# Altium schematic sheet Sheet1.SchDoc
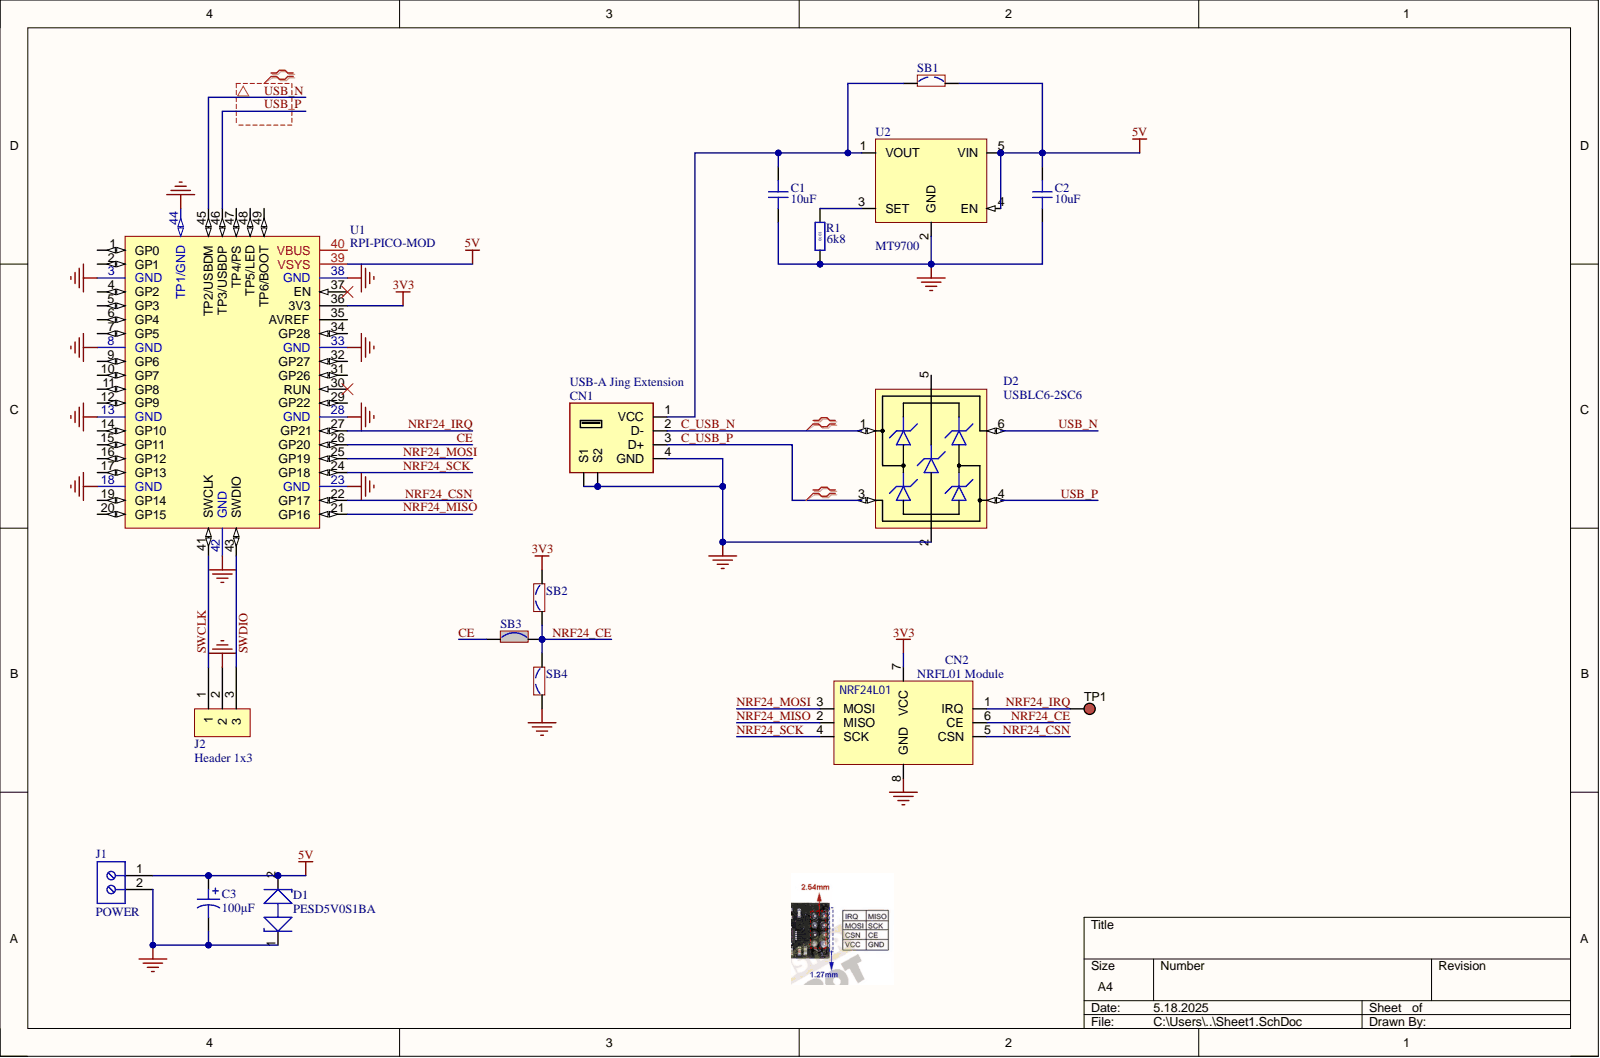
[diagram p0: Sheet1.SchDoc]

## Parts
C1  10uF  [CAP_0603]
C2  10uF  [CAP_0603]
C3  100µF  [CAP TH ALUM ELEC D5.00mm S2.00mm H12.50mm]
CN1  USB-A Jing Extension  [USB-A Jing Extension-Footprint-1]
CN2  NRFL01 Module  [Copy of HDR2X4]
D1  PESD5V0S1BA  Rep Pk Reverse Voltage-Max: 5V  [FP-SOD323-CA-MFG]
D2  USBLC6-2SC6  [SOT-23-6]
J1  POWER  [691102710002]
J2  Header 1x3  [Header 1x3 male]
R1  6k8  [RES_0603]
SB1  SB_OFF  [RESC1608X55X25ML10T15]  (value not drawn)
SB2  SB_OFF  [RESC1608X55X25ML10T15]  (value not drawn)
SB3  SB_ON  [RESC1608X55X25ML10T15]  (value not drawn)
SB4  SB_OFF  [RESC1608X55X25ML10T15]  (value not drawn)
TP1  5003  [KSTN-5003]  (value not drawn)
U1  RPI-PICO-MOD  [RPI-PICO-MOD]
U2  MT9700  [SOT-23-5]

## Nets
3V3: CN2.7(VCC) SB2.2 U1.36(3V3)
5V: C2.2 C3.1 D1.2 J1.1 SB1.2 U1.39(VSYS) U2.4(EN) U2.5(VIN)
GND: C1.1 C2.1 C3.2 CN1.4(GND) CN1.5(S1) CN1.6(S2) CN2.8(GND) D1.1 D2.2 J1.2 J2.2 R1.1 SB4.1 U1.3(GND) U1.8(GND) U1.13(GND) U1.18(GND) U1.23(GND) U1.28(GND) U1.33(GND) U1.38(GND) U1.42(GND) U1.44(TP1/GND) U2.2(GND)
C_USB_N: CN1.2(D-) D2.1
C_USB_P: CN1.3(D+) D2.3
CE: SB3.1 U1.26(GP20)
NRF24_CE: CN2.6(CE) SB2.1 SB3.2 SB4.2
NRF24_CSN: CN2.5(CSN) U1.22(GP17)
NRF24_IRQ: CN2.1(IRQ) TP1.1 U1.27(GP21)
NRF24_MISO: CN2.2(MISO) U1.21(GP16)
NRF24_MOSI: CN2.3(MOSI) U1.25(GP19)
NRF24_SCK: CN2.4(SCK) U1.24(GP18)
SWCLK: J2.1 U1.41(SWCLK)
SWDIO: J2.3 U1.43(SWDIO)
USB_N: D2.6 U1.45(TP2/USBDM)
USB_P: D2.4 U1.46(TP3/USBDP)
NetC1_2: C1.2 CN1.1(VCC) SB1.1 U2.1(VOUT)
NetR1_2: R1.2 U2.3(SET)

Not connected: D2 5; U1 1(GP0) 2(GP1) 4(GP2) 5(GP3) 6(GP4) 7(GP5) 9(GP6) 10(GP7) 11(GP8) 12(GP9) 14(GP10) 15(GP11) 16(GP12) 17(GP13) 19(GP14) 20(GP15) 29(GP22) 30(RUN) 31(GP26) 32(GP27) 34(GP28) 35(AVREF) 37(EN) 40(VBUS) 47(TP4/PS) 48(TP5/LED) 49(TP6/BOOT)

## Library data of the parts
C1 C2 = CMP-001-00086-1: Capacitor SMD 0603; Component Kind: Standard; Design Item ID: CAP_0603; Library Name: Simon Mayr; Source: Schematic-Lib-PhilsLab.SchLib
C3 = 16PX100MEFC5X11: CAP ALUM 100UF 20% 16V RADIAL; manufacturer: Rubycon; Database Table Name: Capacitors - Aluminum - Electrolytic TH; Lifetime @ Temp.: 1000 Hrs @ 105°C; Polarization: Polar; Ripple Current @ High Frequency: 156mA @ 10kHz; Ripple Current @ Low Frequency: 130mA @ 120Hz; Applications: General Purpose; Capacitance: 100µF; Component Kind: Standard; componentlink1description: Datasheet; componentlink1url: http://www.rubycon.co.jp/en/catalog/e_pdfs/aluminum/e_px.pdf; componentlink2description: DigiKey Link; componentlink2url: http://digikey.com/product-detail/en/rubycon/16PX100MEFC5X11/1189-2931-ND/5866573; Device Package: Radial, Can; Height - Seated (Max): 0.492" (12.50mm); lastupdated: 04.09.2020 23:29:00; Lead Spacing: 0.079" (2.00mm); Library Name: Celestial Altium Library - altium_library.DbLib; Lifecycle Status: Active; Minimum Order: 1; Mounting Type: Through Hole; Operating Temperature: -55°C ~ 105°C; Package / Case: Radial, Can; packaging: Bulk; Part Number: 16PX100MEFC5X11; Part Status: Active; partid: 21978; price: 0.24; series: PX; Size / Dimension: 0.197" Dia (5.00mm); Supplier 1: DigiKey; Supplier Part Number 1: 1189-2931-ND; Tolerance: ±20%; value: 100µF; Voltage - Rated: 16V
CN1 = CMP-002-00465-2: USB 2.0 1 4P Female Type-A Plugin USB Connectors ROHS; Component Kind: Standard; Gender: female; Library Name: Simon Mayr
CN2 = CMP-002-00466-2: NRF24L01 PLUG IN Module; Gender: Female; Component Kind: Standard; Pins: 8; Library Name: Simon Mayr
D1 = CMP-004-00032-2: 20A 9V 300W 7V Bidirectional 5V SOD-323 ESD and Surge Protection (TVS/ESD); Diode Type: TRANS VOLTAGE SUPPRESSOR; Max Breakdown Voltage: 9.5V; Min Breakdown Voltage: 5.5V; Non-rep Peak Rev Power Dis-Max: 130W; Component Kind: Standard; Max Operating Temperature: 150°C; Pins: 2; Polarity: Bidirectional; RoHS Compliant: Yes; Library Name: Simon Mayr
D2 = CMP-004-00040-1: Low-Capacitance ESD Protection USB; Manufacturer: STMicroelectronics; Component Kind: Standard; Design Item ID: USBLC6-2SC6; Distributor Link: https://www.mouser.de/ProductDetail/STMicroelectronics/USBLC6-2SC6?qs=po45yt2pPpu%2FhNIlwQdTlg%3D%3D; Manufacturer Part Number: USBLC6-2SC6; Library Name: Simon Mayr; Source: Schematic-Lib-PhilsLab.SchLib
J1 = CMP-002-00468-1: Barrier Strip Terminal Block; Component Kind: Standard; RoHS Compliant: Yes; Library Name: Simon Mayr
J2 = CMP-002-00154-1: Component Kind: Standard; Design Item ID: Header 1x3; Source: Headers (1).SchLib; Library Name: Simon Mayr
R1 = CMP-009-00189-1: Resistor SMD 0603; Component Kind: Standard; Design Item ID: RES_0603; Library Name: Simon Mayr; Source: Schematic-Lib-PhilsLab.SchLib
SB1 SB2 SB4 = CMP-009-00179-7: Solder Bridge OFF; Package Width: 0.8mm; Power: 100mW; Rated Temperature: 70°C; Value: 0; Case/Package: 0603; Component Kind: Standard; Construction: Chip; JESD-609 Code: e3; Max Operating Temperature: 155°C; Min Operating Temperature: -55°C; Mounting Technology: Surface Mount; Package Shape: Rectangular; Package Style: SMTMeter; Packaging: Tape and Reel; Pins: 2; Reach SVHC Compliant: Yes; Resistor Type: FIXED RESISTOR; RoHS Compliant: Yes; Technology: METAL GLAZE/THICK FILM; Terminal Finish: Nickel; Termination: Wraparound; Library Name: Simon Mayr
SB3 = CMP-00003-3: Solder Bridge ON; Package Width: 0.8mm; Power: 100mW; Rated Temperature: 70°C; Value: 0; Case/Package: 0603; Component Kind: Standard; Construction: Chip; JESD-609 Code: e3; Max Operating Temperature: 155°C; Min Operating Temperature: -55°C; Mounting Technology: Surface Mount; Package Shape: Rectangular; Package Style: SMTMeter; Packaging: Tape and Reel; Pins: 2; Reach SVHC Compliant: Yes; Resistor Type: FIXED RESISTOR; RoHS Compliant: Yes; Technology: METAL GLAZE/THICK FILM; Terminal Finish: Nickel; Termination: Wraparound; Library Name: Simon Mayr
TP1 = CMP-002-00037-2: Test Point, Orange, Height 4.6 mm, Tail Length 3 mm, 1-Pin THD, RoHS; Manufacturer: Keystone; Case/Package: 5003; Colour: Orange; Component Kind: Standard; Connector Type: TestPoint; Contact Material: Bronze; Contact Plating: Tin; Datasheet URL: http://keyelco.com/userAssets/file/M65p56.pdf; Datasheet Version: Jun-15; Diameter: 2.54mm; Manufacturer URL: http://www.keyelco.com/; Mounting Technology: TH; Package Description: 1-Pin Through Hole Device, Dia 2.54 mm; Package Quantity: 10; Package Reference: 5003; Packaging: Bulk; Pins: 1; RoHS Compliant: True; Library Name: Simon Mayr
U1 = CMP-096-000000-1: RASPBERRY PI PICO RP2040 BOARD; Manufacturer: Raspberry Pi Foundation; Manufacturer Part No: SC0915; Component Kind: Standard; Design Item ID: RPI-PICO-MOD; Mounting Technology: SMT; Source: RPi_Pico.SchLib; Supplier Link: https://www.digikey.com/en/products/detail/raspberry-pi/SC0915/13684020; Supplier Part No: 2648-SC0915CT-ND; Library Name: Simon Mayr
U2 = CMP-011-00017-1: Adjustable Current-Limited Power Distribution Switch; Manufacturer: Aerosemi; Component Kind: Standard; Design Item ID: MT9700; Manufacturer Part Number: MT9700; Library Name: Simon Mayr; Source: Schematic-Lib-PhilsLab.SchLib
